# Altium schematic sheet Power.SchDoc(Power)
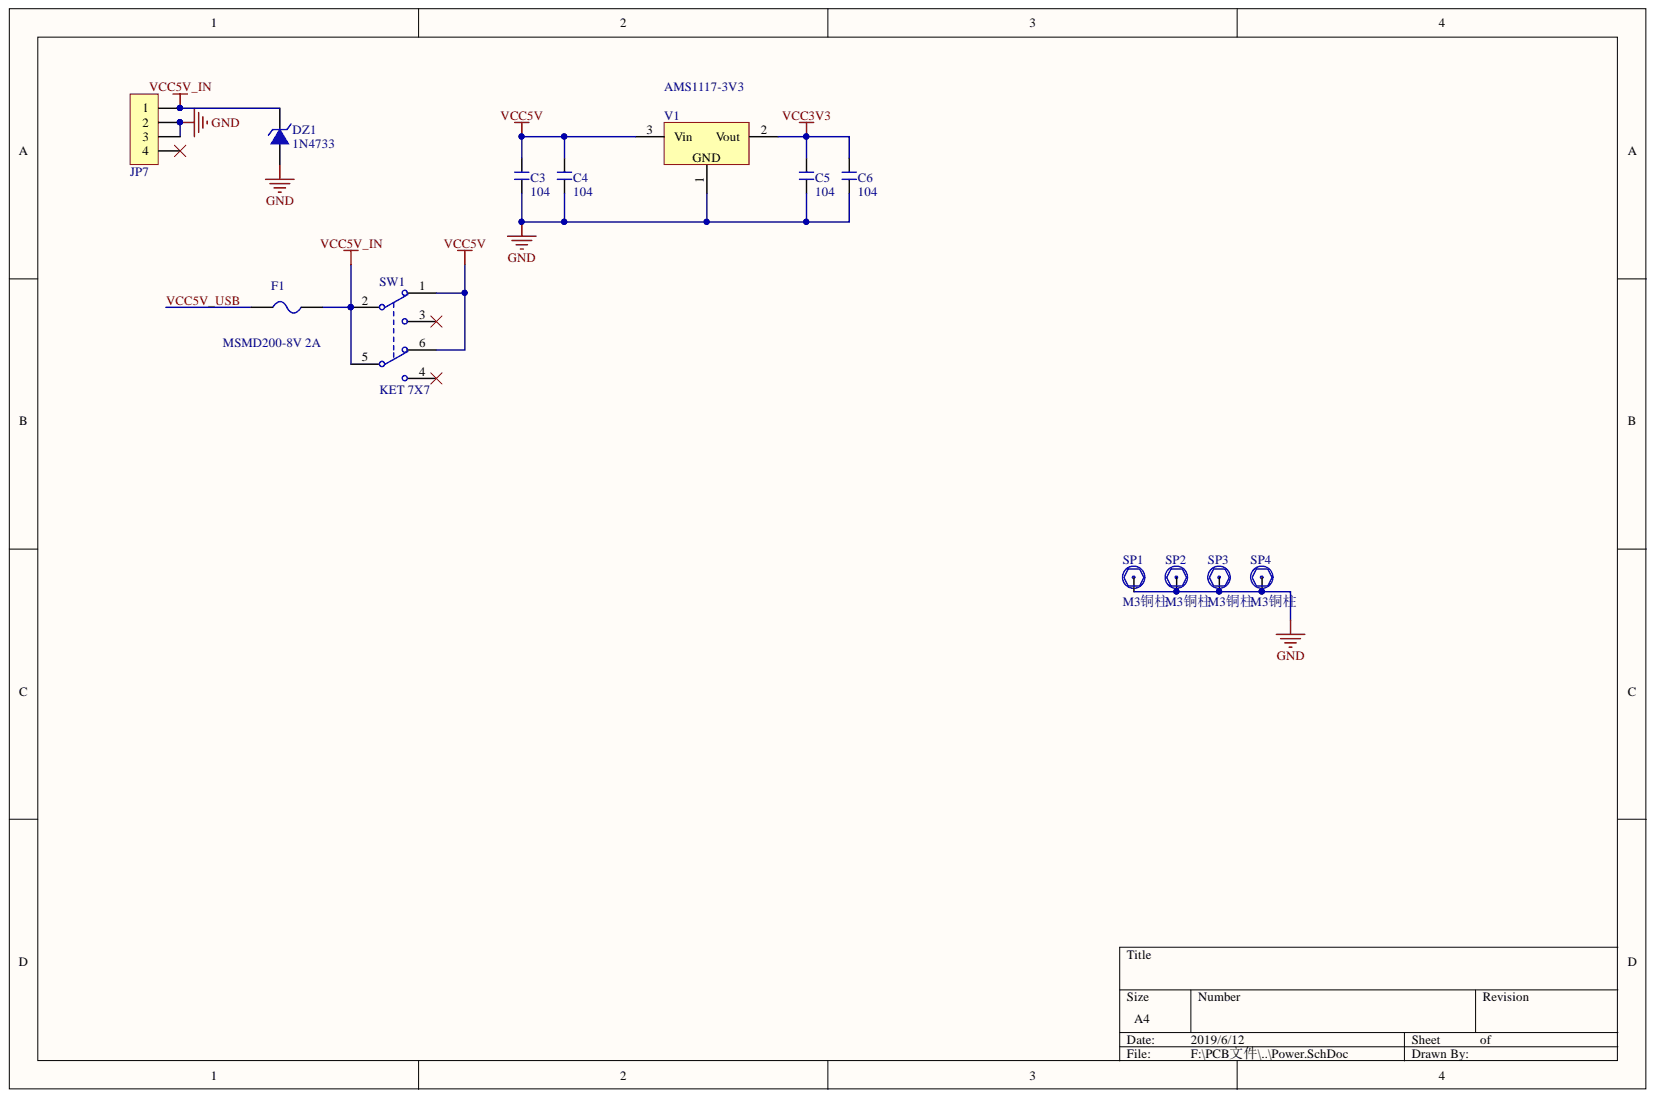
[diagram p0: Power.SchDoc]

## Parts
C3  104  [C 1812_L]
C4  104  [C 1812_L]
C5  104  [C 1206_M]
C6  104  [C 1206_M]
DZ1  1N4733  [SMA]
F1  MSMD200-8V 2A  [FU 1210]
JP7  HDR-1X4  [VH3.96-LI-4P]  (value not drawn)
SP1  M3铜柱  [M3x4_L]
SP2  M3铜柱  [M3x4_L]
SP3  M3铜柱  [M3x4_L]
SP4  M3铜柱  [M3x4_L]
SW1  KET 7X7  [KFT DIP-7X7]
V1  AMS1117-3V3  [SOT223_L]

## Nets
GND: C3.1 C4.1 C5.1 C6.1 DZ1.1 JP7.2 JP7.3 SP1.1 SP2.1 SP3.1 SP4.1 V1.1
VCC3V3: C5.2 C6.2 V1.2
VCC5V: C3.2 C4.2 SW1.1 SW1.6 V1.3
VCC5V_IN: DZ1.2 F1.2 JP7.1 SW1.2 SW1.5
VCC5V_USB: F1.1

Not connected: JP7 4; SW1 3 4

## Library data of the parts
C3-C6 = C: 无极性贴片电容; 版权归属: 源创客; 学习资源: www.ooocke.com; Library Name: 3.1   - 电阻-电容-电感.SchLib; Component Kind: Standard; BOM名称: 无极性贴片电容
DZ1 = 1N4733: 5.1V/1W稳压管; 版权归属: 源创客; 学习资源: www.ooocke.com; Library Name: 8.1   - 二极管-整流桥.SchLib; Component Kind: Standard; BOM名称: 5.1V/1W稳压管
F1 = FU: 贴片保险丝; 版权归属: 源创客; 学习资源: www.ooocke.com; Library Name: 10.1 - 保险丝-晶振.SchLib; Component Kind: Standard; BOM名称: 贴片保险丝
JP7 = Header 4: 4P接插件; 版权归属: 源创客; 学习资源: www.ooocke.com; Library Name: 4.8   - 接插件-脚距3.96.SchLib; Component Kind: Standard; BOM名称: 4P接插件
SP1-SP4 = 铜柱小焊盘: 铜柱; 版权归属: 源创客; 学习资源: www.ooocke.com; Library Name: 15.1 - 未分类器件.SchLib; Component Kind: Standard; BOM名称: 铜柱
SW1 = KET 7X7: 7*7自锁开关; 版权归属: 源创客; 学习资源: www.ooocke.com; Library Name: 5.1   - 按键-开关.SchLib; Component Kind: Standard; BOM名称: 7*7自锁开关
V1 = AMS1117: 三端稳压芯片; 版权归属: 源创客; BOM名称: 稳压芯片; 学习资源: www.ooocke.com; Library Name: 1.3   - 电源类芯片.SchLib; Component Kind: Standard
